# Altium schematic sheet LVDS Interface.SchDoc
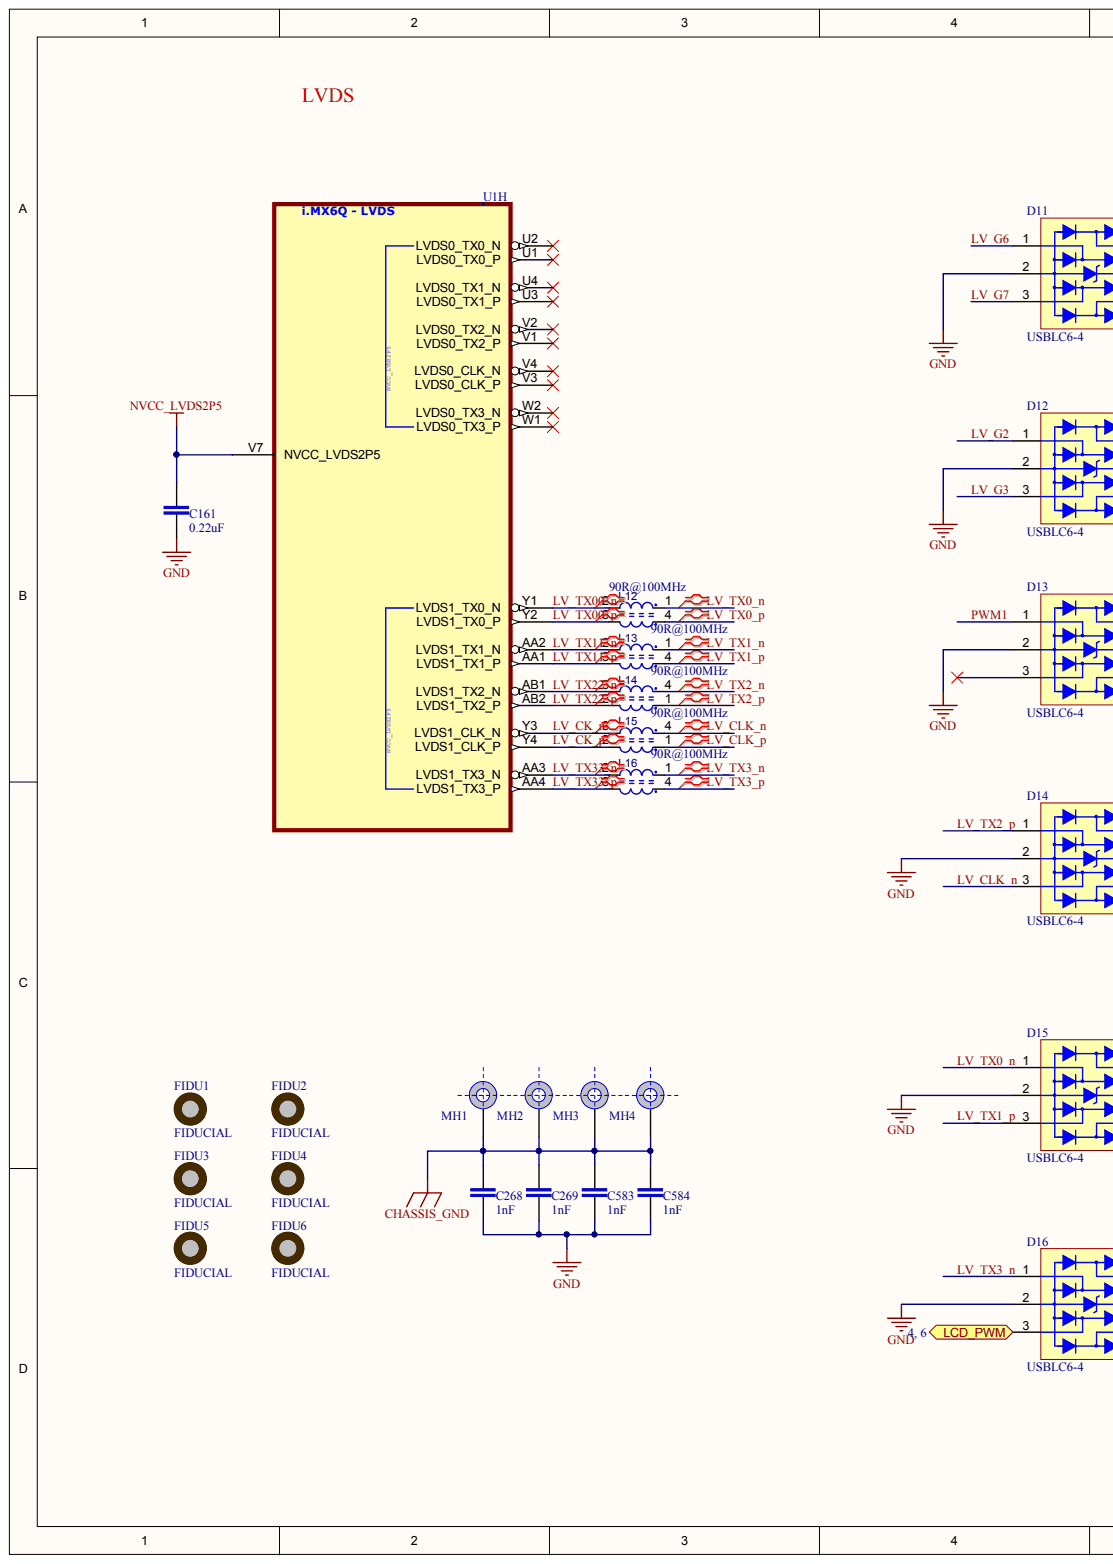
[diagram p0: LVDS Interface.SchDoc, left]
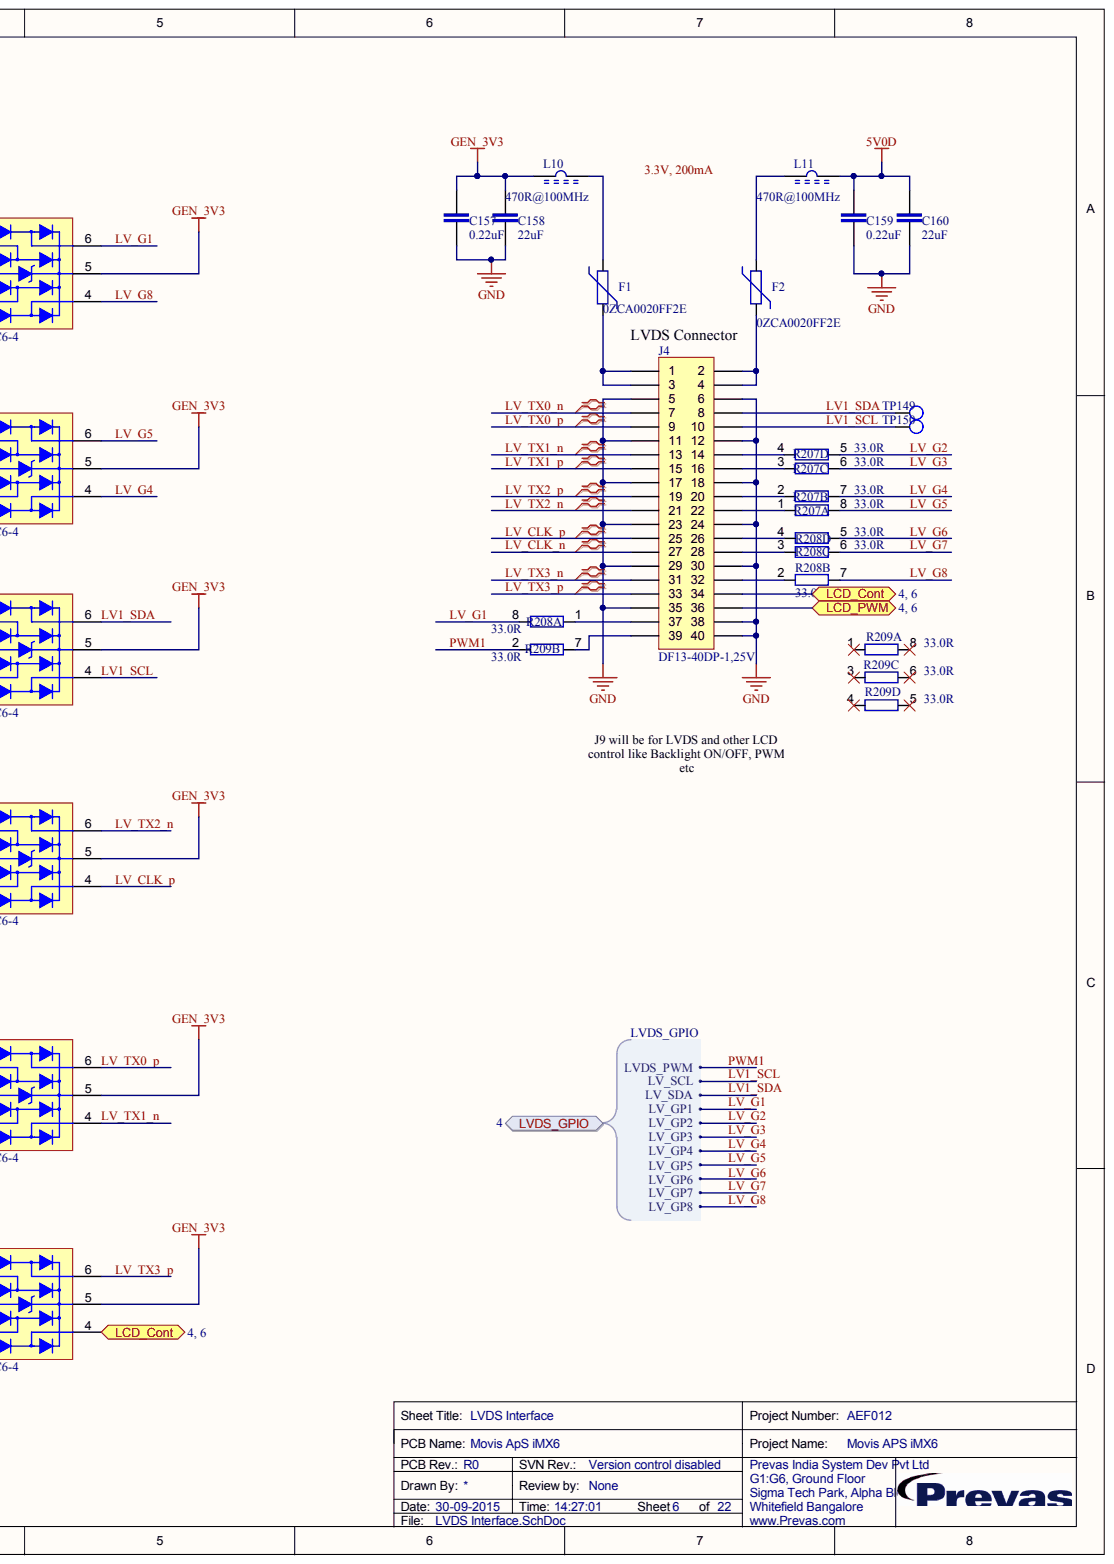
[diagram p1: LVDS Interface.SchDoc, right]

## Parts
C157  0.22uF  [CAPC0603X33N]
C158  22uF  [CAPC3216X180N]
C159  0.22uF  [CAPC0603X33N]
C160  22uF  [CAPC3216X180N]
C161  0.22uF  [CAPC1005X55N]
C268  1nF  [CAPC1608X87N]
C269  1nF  [CAPC1608X87N]
C583  1nF  [CAPC1608X87N]
C584  1nF  [CAPC1608X87N]
D11  USBLC6-4  [SOT23-6L]
D12  USBLC6-4  [SOT23-6L]
D13  USBLC6-4  [SOT23-6L]
D14  USBLC6-4  [SOT23-6L]
D15  USBLC6-4  [SOT23-6L]
D16  USBLC6-4  [SOT23-6L]
F1  elec_value: 0ZCA0020FF2E  [FUSE_0ZCA0020FF2E]  (hidden comment: Fuse_PTC)
F2  elec_value: 0ZCA0020FF2E  [FUSE_0ZCA0020FF2E]  (hidden comment: Fuse_PTC)
FIDU1  FIDUCIAL  [Fiducial_smd]  (no BOM)
FIDU2  FIDUCIAL  [Fiducial_smd]  (no BOM)
FIDU3  FIDUCIAL  [Fiducial_smd]  (no BOM)
FIDU4  FIDUCIAL  [Fiducial_smd]  (no BOM)
FIDU5  FIDUCIAL  [Fiducial_smd]  (no BOM)
FIDU6  FIDUCIAL  [Fiducial_smd]  (no BOM)
J4  DF13-40DP-1,25V  [Hirose_DF13A-40DP-1.25V]
L10  470R@100MHz  [INDC4516X180N]
L11  470R@100MHz  [INDC4516X180N]
L12  90R@100MHz  [IND_DLP11S_DLP31S]
L13  90R@100MHz  [IND_DLP11S_DLP31S]
L14  90R@100MHz  [IND_DLP11S_DLP31S]
L15  90R@100MHz  [IND_DLP11S_DLP31S]
L16  90R@100MHz  [IND_DLP11S_DLP31S]
MH1  HOLE  [P3.2-6.2]  (value not drawn)  (no BOM)
MH2  HOLE  [P3.2-6.2]  (value not drawn)  (no BOM)
MH3  HOLE  [P3.2-6.2]  (value not drawn)  (no BOM)
MH4  HOLE  [P3.2-6.2]  (value not drawn)  (no BOM)
R207  33.0R  [RESCAXS50P100X200X45-8N]  (parts A,B,C,D)
R208  33.0R  [RESCAXS50P100X200X45-8N]  (parts A,B,C,D)
R209  33.0R  [RESCAXS50P100X200X45-8N]  (parts A,B,C,D)
TP149  Testpoint  [TP_SMD]  (value not drawn)  (no BOM)
TP150  Testpoint  [TP_SMD]  (value not drawn)  (no BOM)
U1  MCIMX6Q6AVT10AC  [BGA624C80P25X25_2100X2100X160]  (value not drawn)  (part H)

## Ports
LCD_CONT, LCD_PWM, LVDS_GPIO, LVDS_GPIO.LV_GP1, LVDS_GPIO.LV_GP2, LVDS_GPIO.LV_GP3, LVDS_GPIO.LV_GP4, LVDS_GPIO.LV_GP5, LVDS_GPIO.LV_GP6, LVDS_GPIO.LV_GP7, LVDS_GPIO.LV_GP8, LVDS_GPIO.LV_SCL, LVDS_GPIO.LV_SDA, LVDS_GPIO.LVDS_PWM

## Nets
5V0D: C159.2 C160.2 L11.2
CHASSIS_GND: C268.1 C269.1 C583.1 C584.1 MH1.0 MH2.0 MH3.0 MH4.0
GEN_3V3: C157.2 C158.2 D11.5 D12.5 D13.5 D14.5 D15.5 D16.5 L10.1
GND: C157.1 C158.1 C159.1 C160.1 C161.1 C268.2 C269.2 C583.2 C584.2 D11.2 D12.2 D13.2 D14.2 D15.2 D16.2 J4.5 J4.6 J4.11 J4.12 J4.17 J4.18 J4.23 J4.24 J4.29 J4.30 J4.35 J4.38 J4.40
NVCC_LVDS2P5: C161.2 U1.V7(NVCC_LVDS2P5)
LCD_PWM: D16.3 J4.36 ; port LCD_PWM
LV1_SCL: D13.4 J4.10 TP150.1 ; port LVDS_GPIO
LV1_SDA: D13.6 J4.8 TP149.1 ; port LVDS_GPIO
LV_CK_N: L15.3 U1.Y3(LVDS1_CLK_N)
LV_CK_P: L15.2 U1.Y4(LVDS1_CLK_P)
LV_CLK_N: D14.3 J4.27 L15.4
LV_CLK_P: D14.4 J4.25 L15.1
LV_G1: D11.6 R208.8 ; port LVDS_GPIO
LV_G2: D12.1 R207.5 ; port LVDS_GPIO
LV_G3: D12.3 R207.6 ; port LVDS_GPIO
LV_G4: D12.4 R207.7 ; port LVDS_GPIO
LV_G5: D12.6 R207.8 ; port LVDS_GPIO
LV_G6: D11.1 R208.5 ; port LVDS_GPIO
LV_G7: D11.3 R208.6 ; port LVDS_GPIO
LV_G8: D11.4 R208.7 ; port LVDS_GPIO
LV_TX00_N: L12.2 U1.Y1(LVDS1_TX0_N)
LV_TX0_N: D15.1 J4.7 L12.1
LV_TX00_P: L12.3 U1.Y2(LVDS1_TX0_P)
LV_TX0_P: D15.6 J4.9 L12.4
LV_TX1_N: D15.4 J4.13 L13.1
LV_TX1_P: D15.3 J4.15 L13.4
LV_TX2_N: D14.6 J4.21 L14.4
LV_TX2_P: D14.1 J4.19 L14.1
LV_TX3_N: D16.1 J4.31 L16.1
LV_TX3_P: D16.6 J4.33 L16.4
LV_TX11_N: L13.2 U1.AA2(LVDS1_TX1_N)
LV_TX11_P: L13.3 U1.AA1(LVDS1_TX1_P)
LV_TX22_N: L14.3 U1.AB1(LVDS1_TX2_N)
LV_TX22_P: L14.2 U1.AB2(LVDS1_TX2_P)
LV_TX33_N: L16.2 U1.AA3(LVDS1_TX3_N)
LV_TX33_P: L16.3 U1.AA4(LVDS1_TX3_P)
PWM1: D13.1 R209.2 ; port LVDS_GPIO
NetD16_4: D16.4 J4.34 ; port LCD_CONT
NetF1_1: F1.1 J4.1 J4.3
NetF1_2: F1.2 L10.2
NetF2_1: F2.1 J4.2 J4.4
NetF2_2: F2.2 L11.1
NetJ4_14: J4.14 R207.4
NetJ4_16: J4.16 R207.3
NetJ4_20: J4.20 R207.2
NetJ4_22: J4.22 R207.1
NetJ4_26: J4.26 R208.4
NetJ4_28: J4.28 R208.3
NetJ4_32: J4.32 R208.2
NetJ4_37: J4.37 R208.1
NetJ4_39: J4.39 R209.7

Not connected: D13 3; R209 1 3 4 5 6 8; U1 U1(LVDS0_TX0_P) U2(LVDS0_TX0_N) U3(LVDS0_TX1_P) U4(LVDS0_TX1_N) V1(LVDS0_TX2_P) V2(LVDS0_TX2_N) V3(LVDS0_CLK_P) V4(LVDS0_CLK_N) W1(LVDS0_TX3_P) W2(LVDS0_TX3_N)

## Library data of the parts
C157 C159 = INNISI-97: CAP CER 0.22UF 10V 10% X5R 0201; ref_part_type: /capacitor/non_polarized/ceramic/; source_manufacturer_1: Murata; source_manufacturer_1_pn: GRM033R61A224KE90D; cae_altium_pcb_status: Prototype; Database Table Name: altium_default; db_rev: 7640; elec_temp_range: -55°C ~ 85°C; phy_height: 0.33mm; phy_mounting: SMD; phy_packaging_type: 0201; ref_datasheet: INNISI-86; ref_keyword: Multilayer Chip Capacitor; cae_altium_sch_status: Prototype; Component Kind: Standard; ComponentLink1Description: Datasheet; ComponentLink1URL: https://cop201.prevas.dk/viewvc/datasheet/INNISI-86.pdf?root=hw_components&view=co; db_created: 2015-08-03 09:38:06.0; db_last_updated: 03-08-2015 09:38:55; db_local_id: INNISI-97; db_status: Prototype; elec_tolerance: 10%; elec_value: 0.22uF; elec_voltage_rating: 10V; phy_material: X5R; Library Name: altium_mysql.dblib
C158 C160 = INNISI-77: CAP CER 22UF 25V 10% X5R 1206; ref_part_type: /capacitor/non_polarized/ceramic/; source_manufacturer_1: Murata Electronics; source_manufacturer_1_pn: GRM31CR61E226KE15L; cae_altium_pcb_status: Prototype; Database Table Name: altium_default; db_rev: 7573; elec_temp_range: -55 to +85 C; phy_height: 1.8mm; phy_mounting: SMD; phy_packaging_type: 1206; ref_datasheet: INNISI-74; ref_keyword: Multilayer chip capacitor; cae_altium_sch_status: Prototype; Component Kind: Standard; ComponentLink1Description: Datasheet; ComponentLink1URL: https://cop201.prevas.dk/viewvc/datasheet/INNISI-74.pdf?root=hw_components&view=co; db_created: 2015-08-03 04:56:21.0; db_last_updated: 03-08-2015 04:56:22; db_local_id: INNISI-77; db_status: Prototype; elec_tolerance: 10%; elec_value: 22uF; elec_voltage_rating: 25V; phy_material: X5R; Library Name: altium_mysql.dblib
C161 = innisi-76: CAP CER 0.22UF 16V 10% X7R 0402; ref_part_type: /capacitor/non_polarized/ceramic/; source_manufacturer_1: Murata; source_manufacturer_1_pn: GRM155R71C224KA12D; cae_altium_pcb_status: Prototype; Database Table Name: altium_default; db_rev: 7572; elec_temp_range: -55 to +125C; phy_height: 0.55mm; phy_mounting: SMD; phy_packaging_type: 0402; ref_datasheet: INNISI-73; ref_keyword: Ceramic capacitor X7R 0402; cae_altium_sch_status: Prototype; Component Kind: Standard; ComponentLink1Description: Datasheet; ComponentLink1URL: https://cop201.prevas.dk/viewvc/datasheet/INNISI-73.pdf?root=hw_components&view=co; db_created: 2015-08-03 04:28:56.0; db_last_updated: 03-08-2015 04:28:58; db_local_id: INNISI-76; db_status: Prototype; elec_tolerance: 10%; elec_value: 0.22uF; elec_voltage_rating: 16V; phy_material: X7R; Library Name: altium_mysql.dblib
C268 C269 C583 C584 = INNISI-101: Ceramic capacitor C0G 0603; ref_part_type: /capacitor/non_polarized/ceramic/; source_manufacturer_1: KEMET; source_manufacturer_1_pn: C0603C102J2GACAUTO; cae_altium_pcb_status: Prototype; Database Table Name: altium_default; db_rev: 7662; elec_temp_range: -55 to 125; elec_tolerance: 5%; elec_voltage_rating: 200V; phy_height: 0.87mm; phy_material: NPO; phy_mounting: SMD; phy_packaging_type: 0603; ref_datasheet: INNISI-88; ref_keyword: Multilayer chip capacitor; cae_altium_sch_status: Prototype; Component Kind: Standard; ComponentLink1Description: Datasheet; ComponentLink1URL: https://cop201.prevas.dk/viewvc/datasheet/INNISI-88.pdf?root=hw_components&view=co; db_created: 2015-08-04 09:54:42.0; db_last_updated: 04-08-2015 09:54:43; db_local_id: INNISI-101; db_status: Prototype; elec_value: 1nF; Library Name: altium_mysql.dblib
D11-D16 = NIMA-155: Dual very low capacitance ESD protection for USB 2.0 up to 480Mb/s; ref_part_type: /diode/transient/; source_manufacturer_1: STMicroelectronics; cae_altium_pcb_status: Prototype; Database Table Name: altium_default; db_rev: 1817; elec_temp_range: -40 to 125; elec_voltage_rating: 5V; phy_height: 1.45mm; phy_mounting: SMD; ref_datasheet: NIMA-115; cae_altium_sch_status: Prototype; Component Kind: Standard; ComponentLink1Description: Datasheet; ComponentLink1URL: https://cop201.prevas.dk/viewvc/datasheet/NIMA-115.pdf?root=hw_components&view=co; db_created: 2009-09-25 21:20:59; db_last_updated: 25-09-2009 21:21:20; db_local_id: NIMA-155; db_status: Prototype; elec_value: USBLC6-4; Mounted: Yes; phy_packaging_type: SOT23-6L; ref_keyword: ESD; source_manufacturer_1_pn: USBLC6-4; Library Name: altium_mysql.dblib
F1 F2 = INRAAN-221: PTC Resettable Fuse 10A 30VDC T/R; ref_part_type: /elmech/fuse/; source_manufacturer_1: BelFuse; cae_altium_pcb_status: Prototype; Database Table Name: altium_default; db_rev: 6922; elec_power_rating: 0.4W; elec_temp_range: -40C to 85C; phy_height: 0.75mm; phy_mounting: SMD; ref_datasheet: INRAAN-206; ref_keyword: Fuse Surface Mount PTC; cae_altium_sch_status: Prototype; Component Kind: Standard; ComponentLink1Description: Datasheet; ComponentLink1URL: https://cop201.prevas.dk/viewvc/datasheet/INRAAN-206.pdf?root=hw_components&view=co; db_created: 2014-12-01 05:29:43.0; db_last_updated: 01-12-2014 05:29:45; db_local_id: INRAAN-221; db_status: Prototype; elec_current_rating: 10A; elec_voltage_rating: 30V DC; source_manufacturer_1_pn: 0ZCA0020FF2E; Library Name: altium_mysql.dblib
FIDU1-FIDU6 = JEPE-46: Fiducial 1mm; ref_part_type: /pcb_item/; cae_altium_pcb_status: Prototype; Database Table Name: altium_default; db_rev: 109; phy_mounting: Other; ref_keyword: Fiducial Mark; cae_altium_sch_status: Prototype; ComponentLink1Description: Datasheet; db_created: 2008-07-09 11:56:57; db_last_updated: 09-07-2008 11:58:12; db_local_id: JEPE-46; db_status: Prototype; elec_value: Fiducial 1mm; Library Name: altium_mysql.dblib
J4 = CHPE-174: DF13 Series, 1.25mm pitch; ref_part_type: /connector/40 pins/; source_manufacturer_1: Hirose; cae_altium_pcb_status: Prototype; Database Table Name: altium_default; db_rev: 3888; elec_current_rating: 1A; elec_temp_range: -35 to 85; elec_voltage_rating: 150VAC; phy_height: 4.80 mm; phy_mounting: SMD; ref_keyword: Socket Connector LCD; cae_altium_sch_status: Prototype; Component Kind: Standard; ComponentLink1Description: Datasheet; db_created: 2011-08-31 14:47:20.0; db_last_updated: 31-08-2011 16:22:18; db_local_id: CHPE-174; db_status: Prototype; elec_value: DF13-40DP-1,25V; source_manufacturer_1_pn: DF13-40DP-1,25V; Library Name: altium_mysql.dblib
L10 L11 = NIMA-36: Chip EMIFIL Inductor Type, 470R@100MHz, 0.05R@0Hz; ref_part_type: /inductor/lossy/; source_manufacturer_1: Murata; source_manufacturer_1_pn: BLM41PG471SN1L; cae_altium_pcb_status: Prototype; Database Table Name: altium_default; db_rev: 5393; elec_current_rating: 2.0A; elec_frequency_rating: 100MHz; elec_temp_range: -55 to 125; elec_tolerance: 25%; phy_height: 1.8mm; phy_material: Ferrite; phy_mounting: SMD; phy_packaging_type: 1806; ref_datasheet: NIMA-29; ref_keyword: Ferrite bead 1806; cae_altium_sch_status: Prototype; Component Kind: Standard; ComponentLink1Description: Datasheet; ComponentLink1URL: https://cop201.prevas.dk/viewvc/datasheet/NIMA-29.pdf?root=hw_components&view=co; db_created: 2009-03-20 21:04:27; db_last_updated: 05-12-2012 15:13:29; db_local_id: NIMA-36; db_status: Prototype; elec_value: 470R@100MHz; Library Name: altium_mysql.dblib
L12-L16 = NIMA-45: Choke, Common Mode 90R@100MHz; ref_part_type: /inductor/lossy/; source_manufacturer_1: Murata; source_manufacturer_1_pn: DLP11SN900HL2L; cae_altium_pcb_status: Prototype; Database Table Name: altium_default; db_rev: 1364; elec_current_rating: 0.15A; elec_frequency_rating: 100MHz; elec_temp_range: -40 to 85; elec_tolerance: 20%; elec_voltage_rating: 5V; phy_height: 0.92mm; phy_material: Ferrite; phy_mounting: SMD; phy_packaging_type: 0504; ref_datasheet: NIMA-38; cae_altium_sch_status: Prototype; Component Kind: Standard; ComponentLink1Description: Datasheet; ComponentLink1URL: https://cop201.prevas.dk/viewvc/datasheet/NIMA-38.pdf?root=hw_components&view=co; db_created: 2009-03-25 17:22:37; db_last_updated: 02-06-2009 11:13:05; db_local_id: NIMA-45; db_status: Prototype; elec_value: 90R@100MHz; Mounted: Yes; ref_keyword: Choke, Common Mode 90R@100MHz; Library Name: altium_mysql.dblib
MH1-MH4 = NIMA-5: Plated Hole Ø3.2/6.2mm for M3 screw; ref_part_type: /pcb_item/; cae_altium_pcb_status: Prototype; Database Table Name: altium_default; db_rev: 1265; elec_value: Ø3.2mm; phy_mounting: Other; cae_altium_sch_status: Prototype; ComponentLink1Description: Datasheet; db_created: 2008-12-01 17:02:15; db_last_updated: 28-04-2009 18:09:16; db_local_id: NIMA-5; db_status: Prototype; ref_keyword: Hole; Library Name: altium_mysql.dblib
R207-R209 = GEPW-18: Resistor Array Convex 4 x 0402; ref_part_type: /resistor/fixed/; source_manufacturer_1: VISHAY; source_manufacturer_1_pn: CRA04S08333R0FTD; cae_altium_pcb_status: Prototype; Database Table Name: altium_default; db_rev: 2104; elec_power_rating: 62.5mW; elec_temp_range: -55 to +155; elec_tolerance: 1%; elec_voltage_rating: 50V; phy_height: 0.45mm; phy_material: Thick film; phy_mounting: SMD; phy_packaging_type: 4x0402; ref_datasheet: GEPW-14; ref_keyword: Thick Film Resistor Array; cae_altium_sch_status: Prototype; Component Kind: Standard; ComponentLink1Description: Datasheet; ComponentLink1URL: https://cop201.prevas.dk/viewvc/datasheet/GEPW-14.pdf?root=hw_components&view=co; db_created: 2008-12-16 09:11:20; db_last_updated: 26-04-2010 10:43:50; db_local_id: GEPW-18; db_status: Prototype; elec_value: 33.0R; Library Name: altium_mysql.dblib
TP149 TP150 = JEPE-114: Test Point SMD; ref_part_type: /pcb_item/; cae_altium_pcb_status: Prototype; Database Table Name: altium_default; db_rev: 3281; cae_altium_sch_status: Prototype; ComponentLink1Description: Datasheet; db_created: 2008-08-18 11:39:42; db_last_updated: 23-03-2011 14:14:06; db_local_id: JEPE-114; db_status: Prototype; elec_value: Test Point; phy_mounting: SMD; ref_keyword: Test  Point; Library Name: altium_mysql.dblib
U1 = INRAAN-5: i.MX 6Dual/6Quad; ref_part_type: /integrated/digital/processor/general/; AGILE_PN: 344-01218; CAD_APPROVED: Yes; cae_altium_pcb_status: Prototype; CLASS: IC; Database Table Name: altium_default; db_rev: 6247; elec_temp_range: -40 to +105; elec_voltage_rating: 1.5V; MFG_NAME01: FREESCALE SEMICONDUCTOR; phy_height: 1.85; phy_mounting: SMD; phy_packaging_type: FCPBGA96_624; ref_datasheet: INRAAN-6; cae_altium_sch_status: Prototype; Component Kind: Standard; ComponentLink1Description: Datasheet; ComponentLink1URL: https://cop201.prevas.dk/viewvc/datasheet/INRAAN-6.pdf?root=hw_components&view=co; db_created: 2013-10-21 12:25:57.0; db_last_updated: 27-12-2013 09:32:57; db_local_id: INRAAN-5; db_status: Prototype; elec_value: MCIMX6Q6AVT10AC; HEIGHT: 2.16MM; MFG_PN01: MCIMX6Q6AVT10AC; ref_keyword: i.MX 6Dual/6Quad; ROHS: Yes; source_manufacturer_1: Freescale Semiconductor; source_manufacturer_1_pn: MCIMX6Q6AVT10AC; Library Name: altium_mysql.dblib
